# Altium schematic sheet 04_POWER.SchDoc
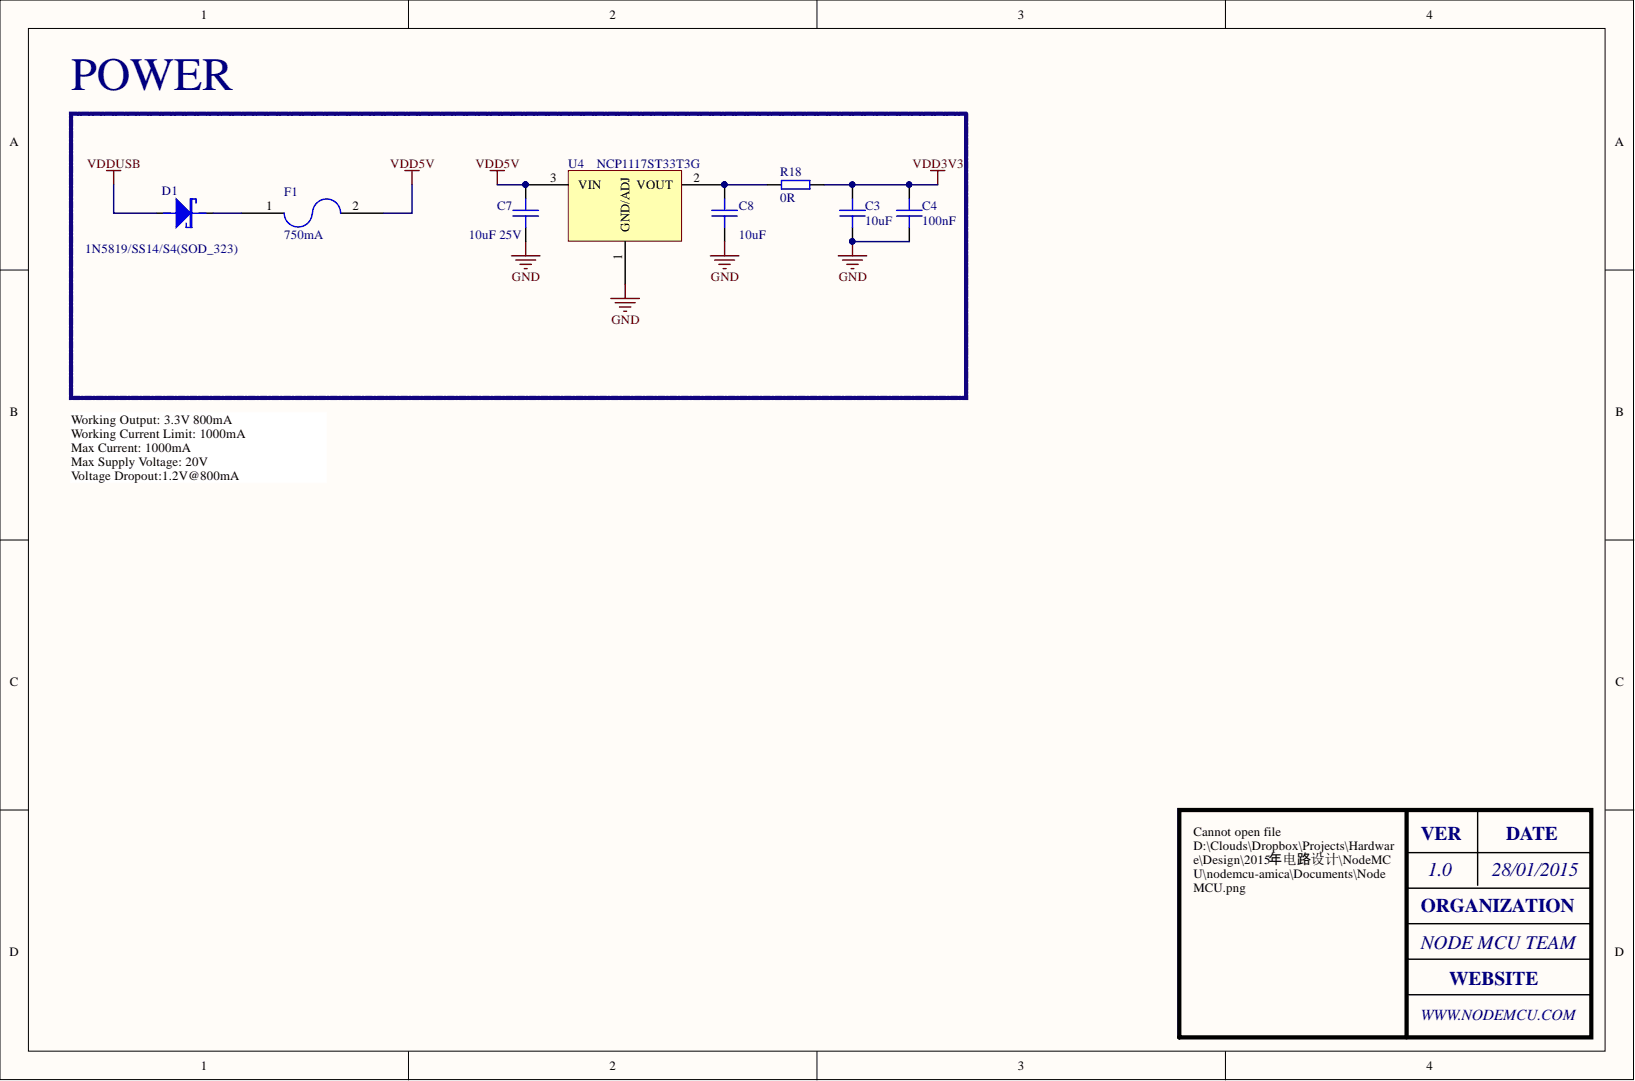
[diagram p0: 04_POWER.SchDoc]

## Parts
C3  10uF  [SMT_C_0402]
C4  100nF  [SMT_C_0402]
C7  10uF 25V  [SMT_C_0805]
C8  10uF  [SMT_C_0402]
D1  1N5819/SS14/S4(SOD_323)  [SMT_DIODE_SOD_323]
F1  750mA  [FUSE0603]
R18  0R  [SMT_R_0402]
U4  NCP1117ST33T3G  [SOT-223]

## Nets
GND: C3.2 C4.2 C7.2 C8.2 U4.1
VDD3V3: C3.1 C4.1 R18.1
VDD5V: C7.1 F1.2 U4.3
VDDUSB: D1.1
NetC8_1: C8.1 R18.2 U4.2
NetD1_2: D1.2 F1.1

## Library data of the parts
C3 C4 C8 = SMT_C_0402: Surface mount capacitor 0402; Component Kind: Standard; Library Name: Vowstar_Basic_Device.IntLib
C7 = SMT_C_0805: Surface mount capacitor 0805; Component Kind: Standard; Library Name: Vowstar_Basic_Device.IntLib
D1 = SMT_DIODE_SCHOTTKY_SOD_323: Surface mount schottky diode SOD-323(0805) Package; Component Kind: Standard; Library Name: Vowstar_Basic_Device.IntLib
F1 = PTC FUSE 750mA: LITTELFUSE - 0603L035YR - RESETTABLE FUSE, PTC, 40A, 6V, 0605; Carrying Current Max: 40; Holding Current: 350; Initial Resistance Max: 1; Operating Voltage: 6; Power Dissipation Pd: 0.5W<!--FANDB--><div class="features-and-benefits-container"><div class="features-and-benefits-description"><span class="features-benefits-value">The POLYFUSE 0603; PTC Fuse Case Style: 0603 [1608 Metric]; Thermistor Mounting: SMD; Tripping Current: 750; Automotive Qualification Standard: -; Component Kind: Standard; ComponentLink1Description: http://www.farnell.com/datasheets/611622.pdf; ComponentLink1URL: http://www.farnell.com/datasheets/611622.pdf; Holding Current Max Ih: 350; Library Name: Fuse.IntLib; Manufacturer: LITTELFUSE; Manufacturer Part Number: 0603L035YR; Operating Temperature Max: 85; Operating Temperature Min: -40; Pricing 1: 10=1.56, 100=1.22, 500=1.03, 1000=0.917, 2000=0.877, 4000=0.86, 20000=0.842, 40000=0.825 (GBP); Product Range: POLYFUSE 0603; RoHS: YES; Stock 1: 2393; Supplier 1: Farnell; Supplier Part Number 1: 1832099; Voltage Rating: 6
R18 = SMT_R_0402: Surface mount resistor 0402; Component Kind: Standard; Library Name: Vowstar_Basic_Device.IntLib
U4 = NCP1117: NCP1117, NCV1117. 1.0 A Low-Dropout Positive  Fixed and Adjustable  Voltage Regulators; Component Kind: Standard; Library Name: Vowstar_IC_General.IntLib
